# Altium schematic sheet 05-User-SMAs.SchDoc(05-User-SMAs)
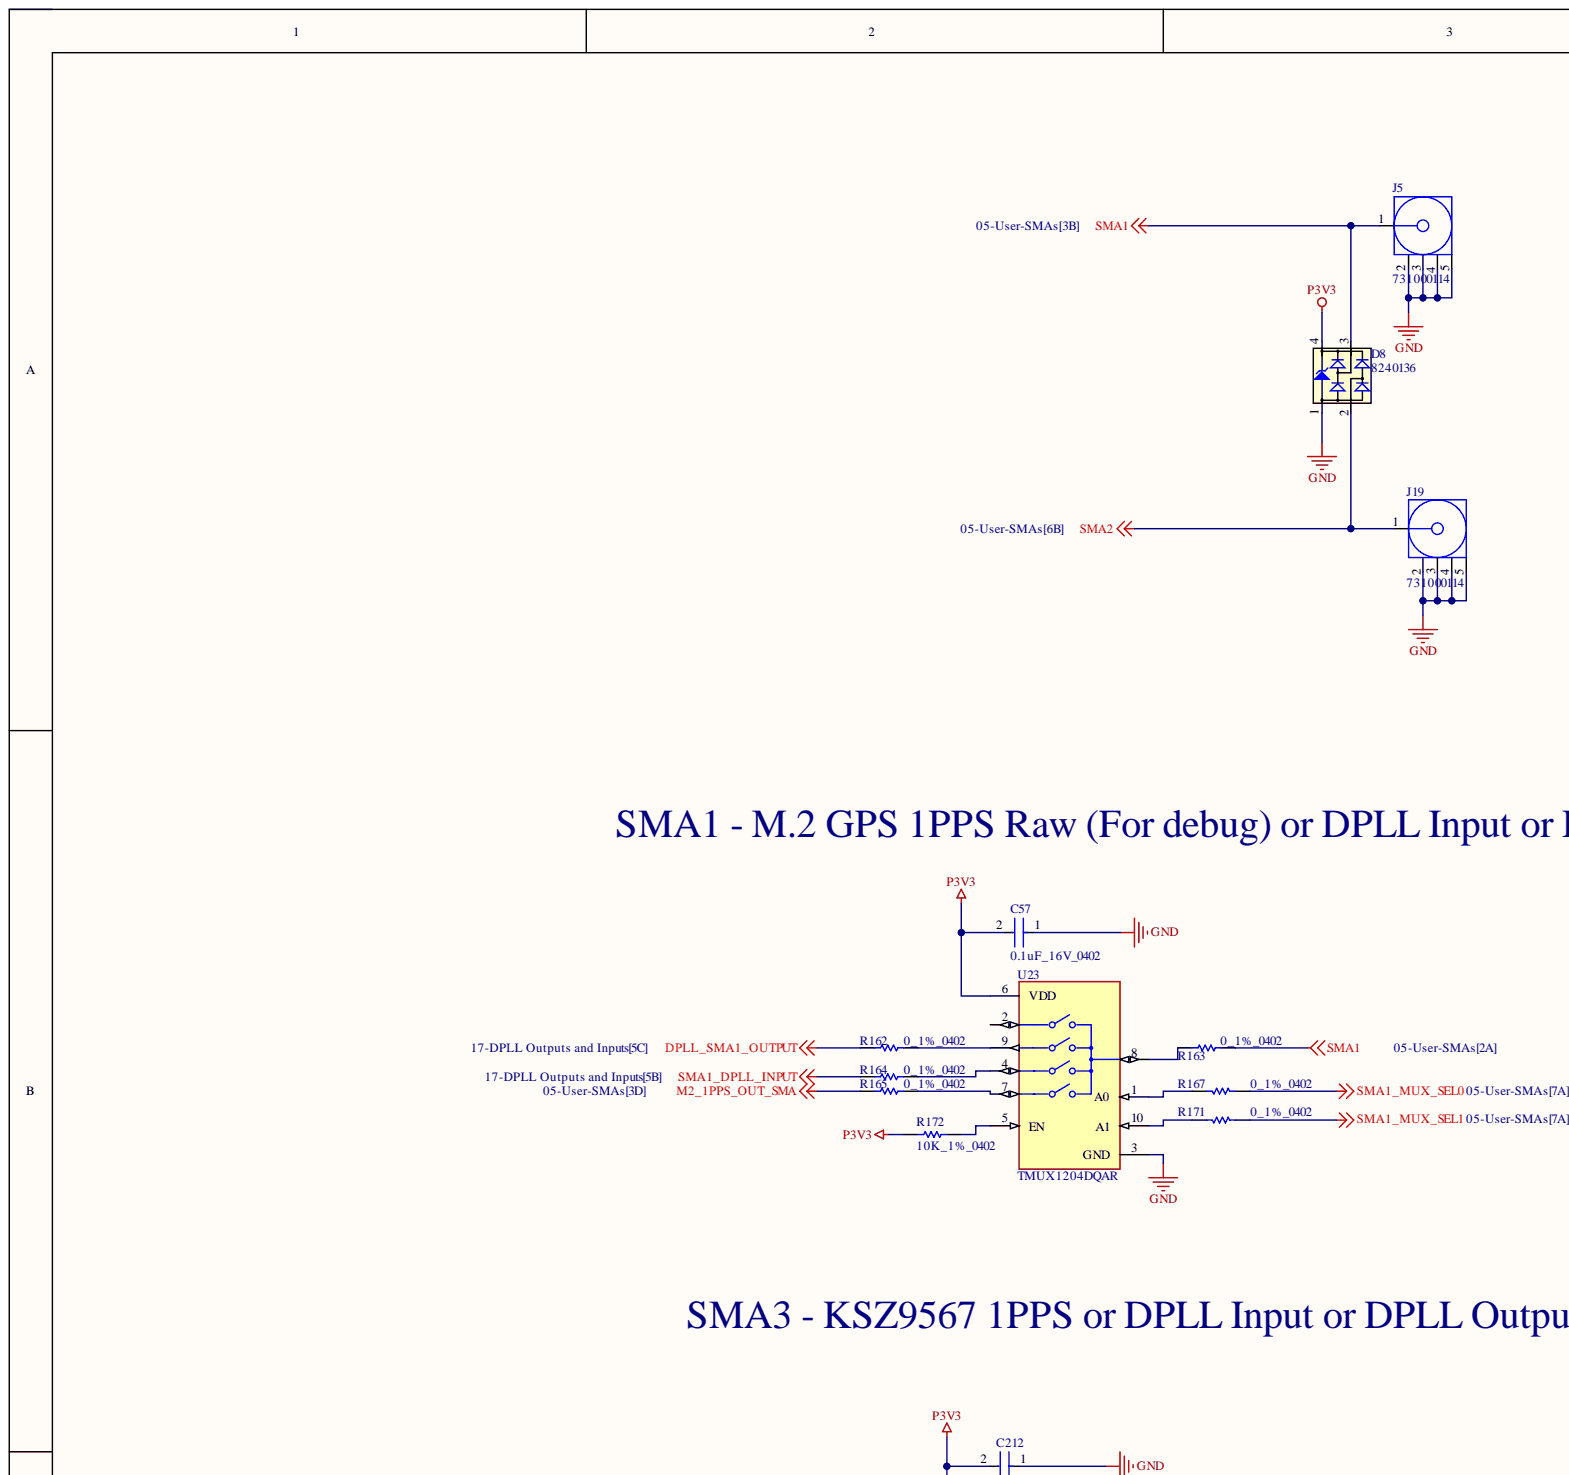
[diagram p0: 05-User-SMAs.SchDoc, top left]
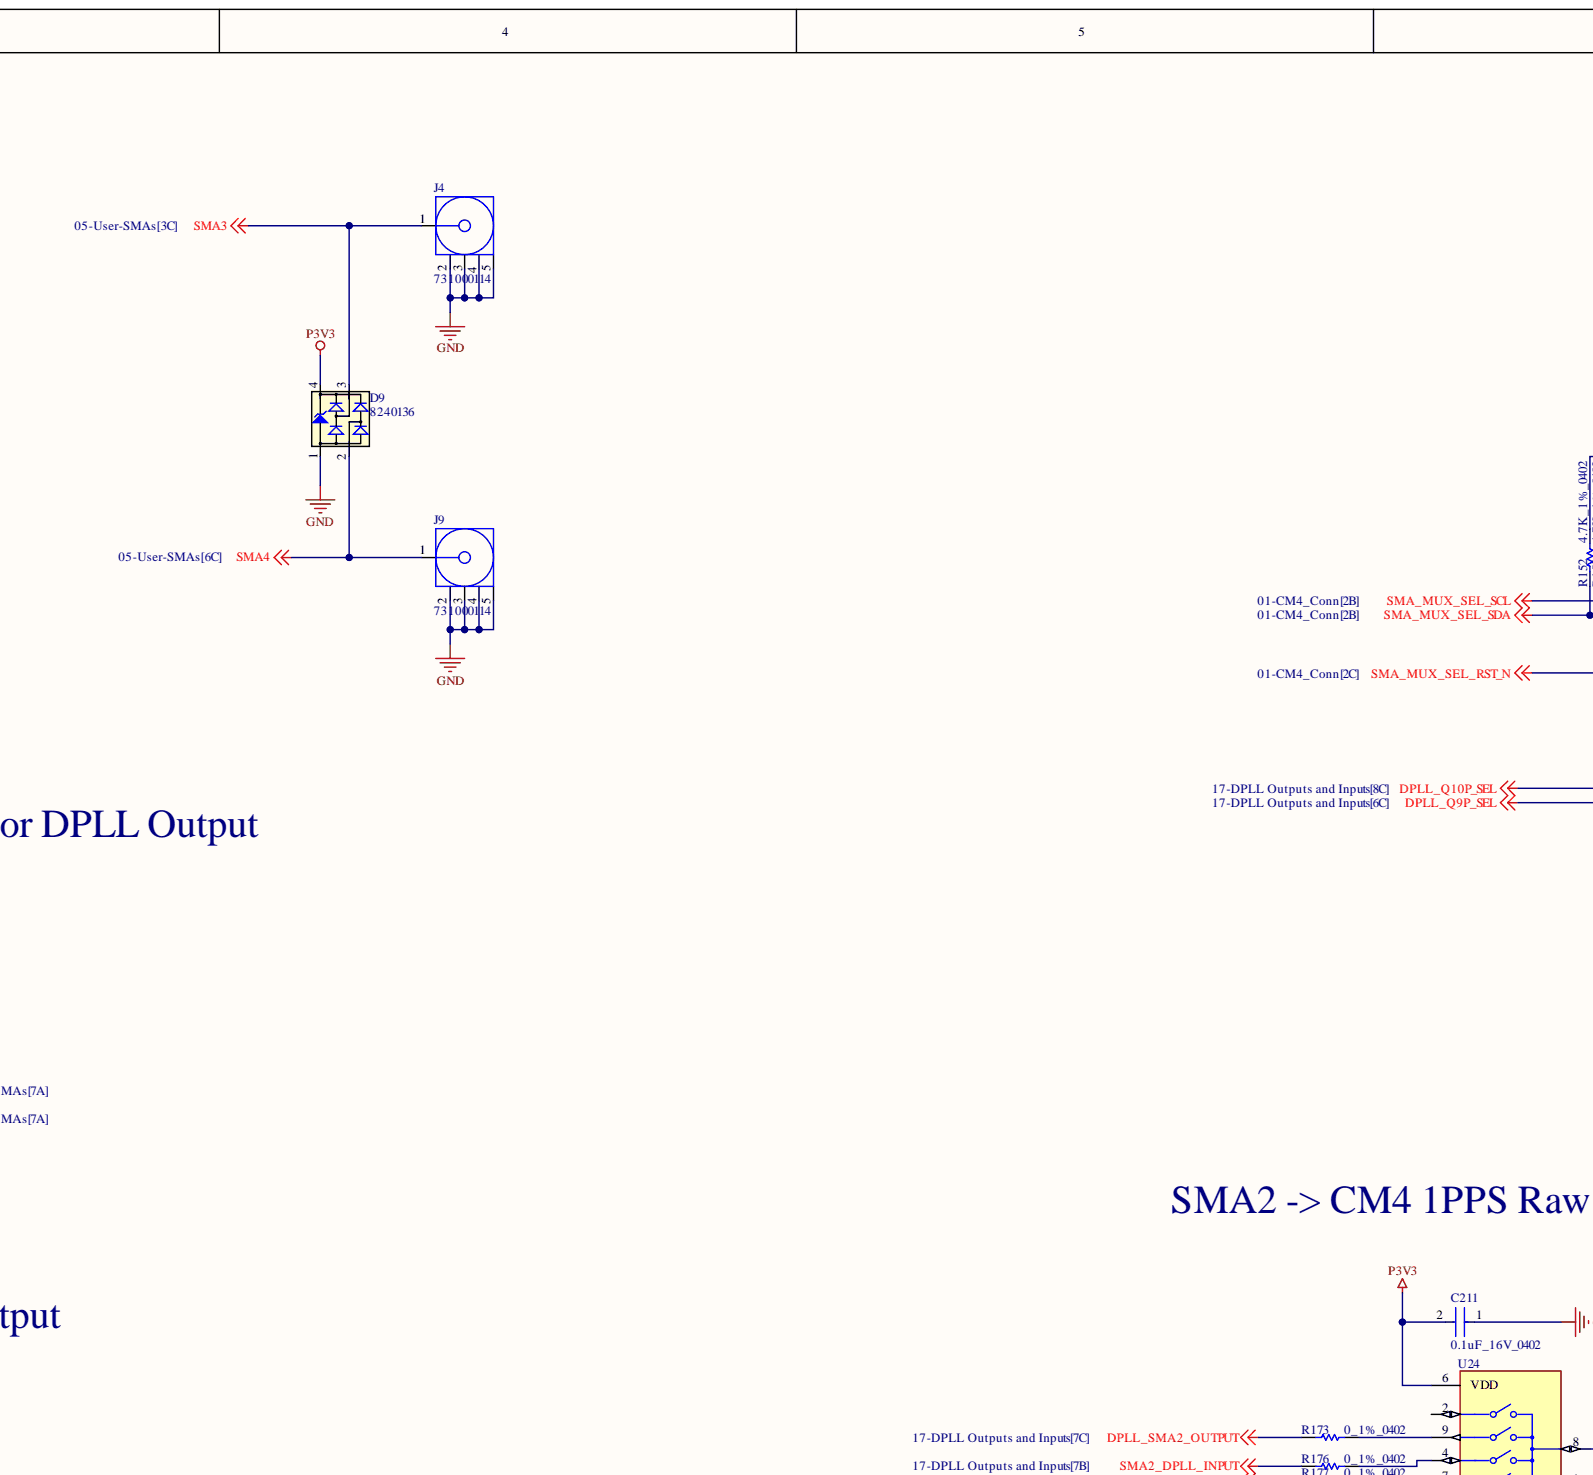
[diagram p1: 05-User-SMAs.SchDoc, top centre]
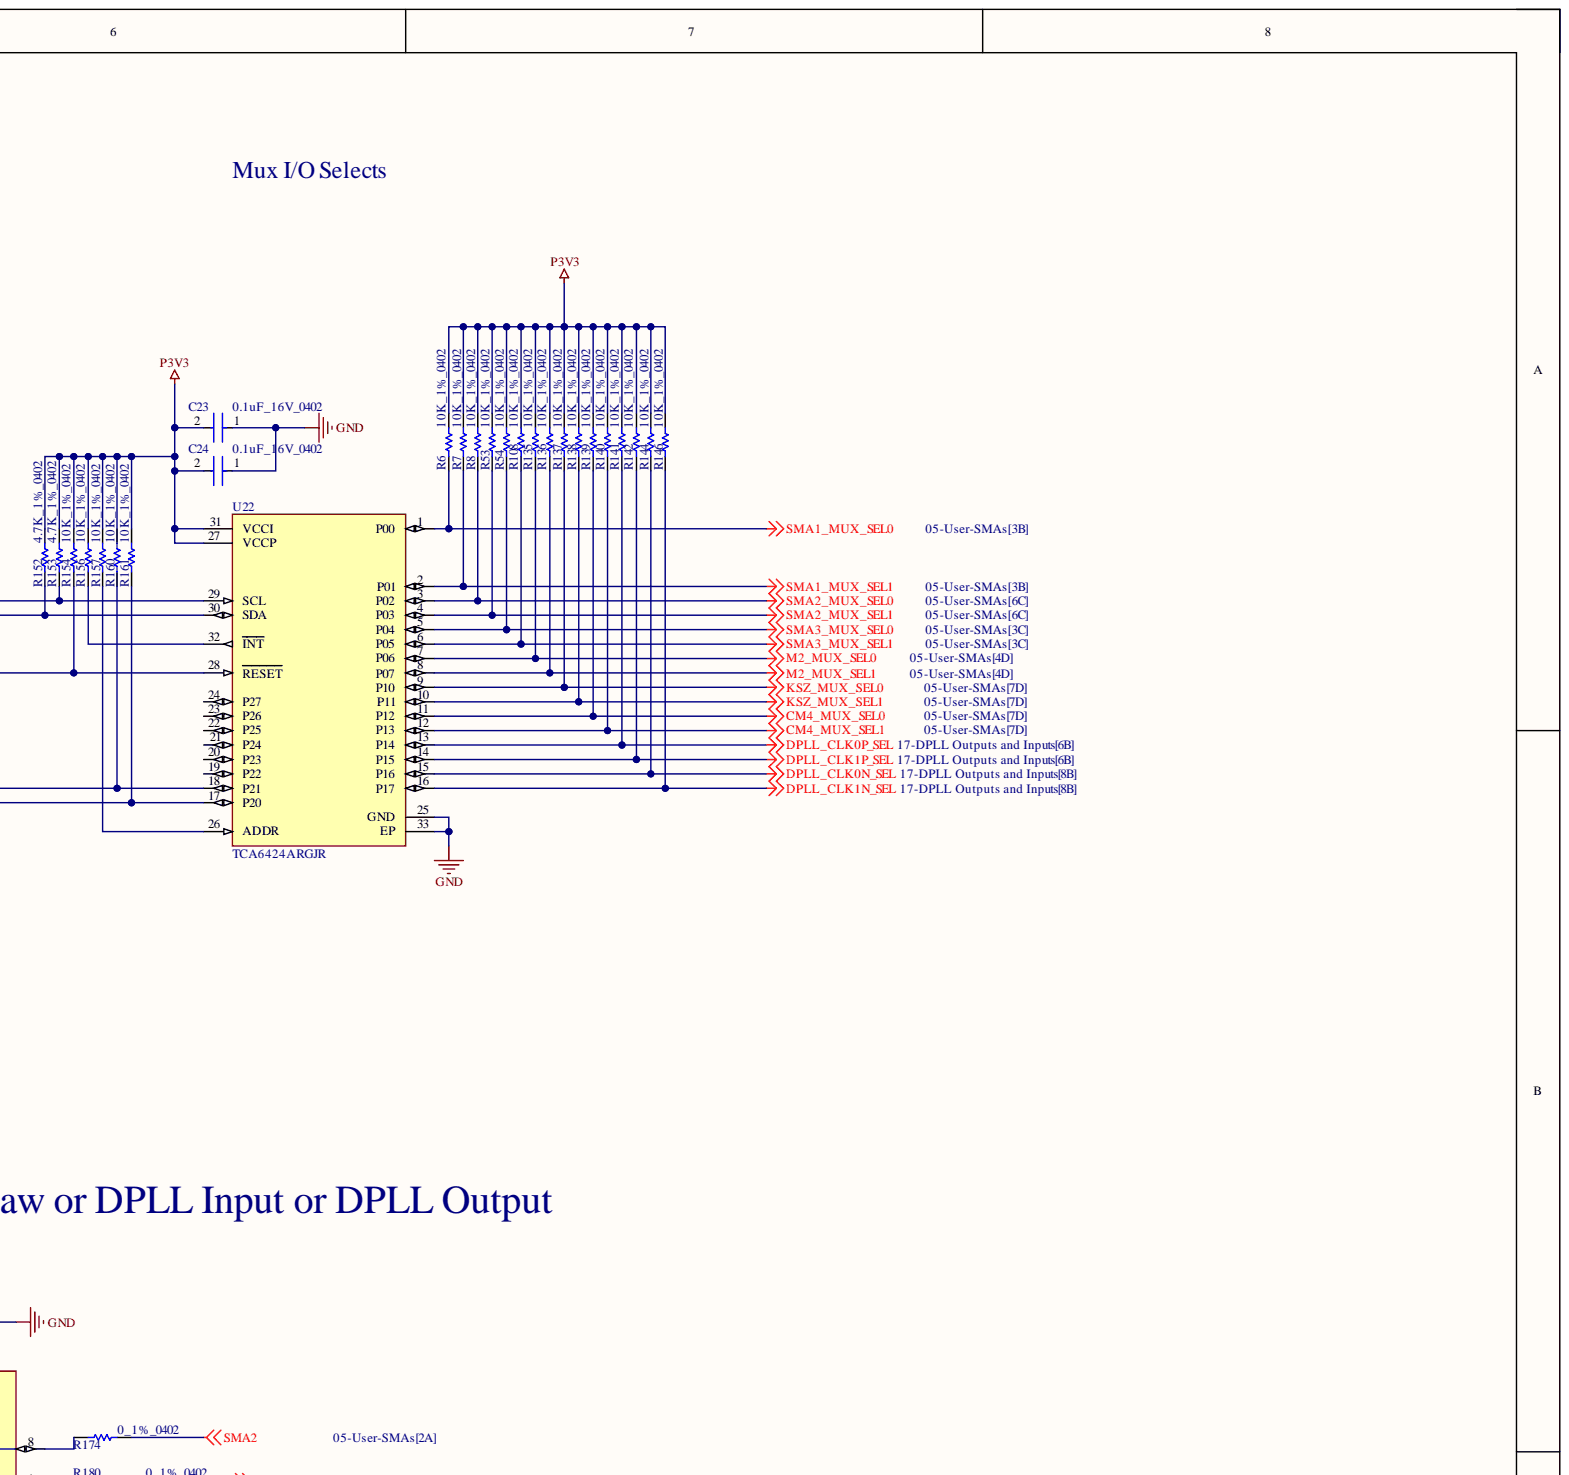
[diagram p2: 05-User-SMAs.SchDoc, top right]
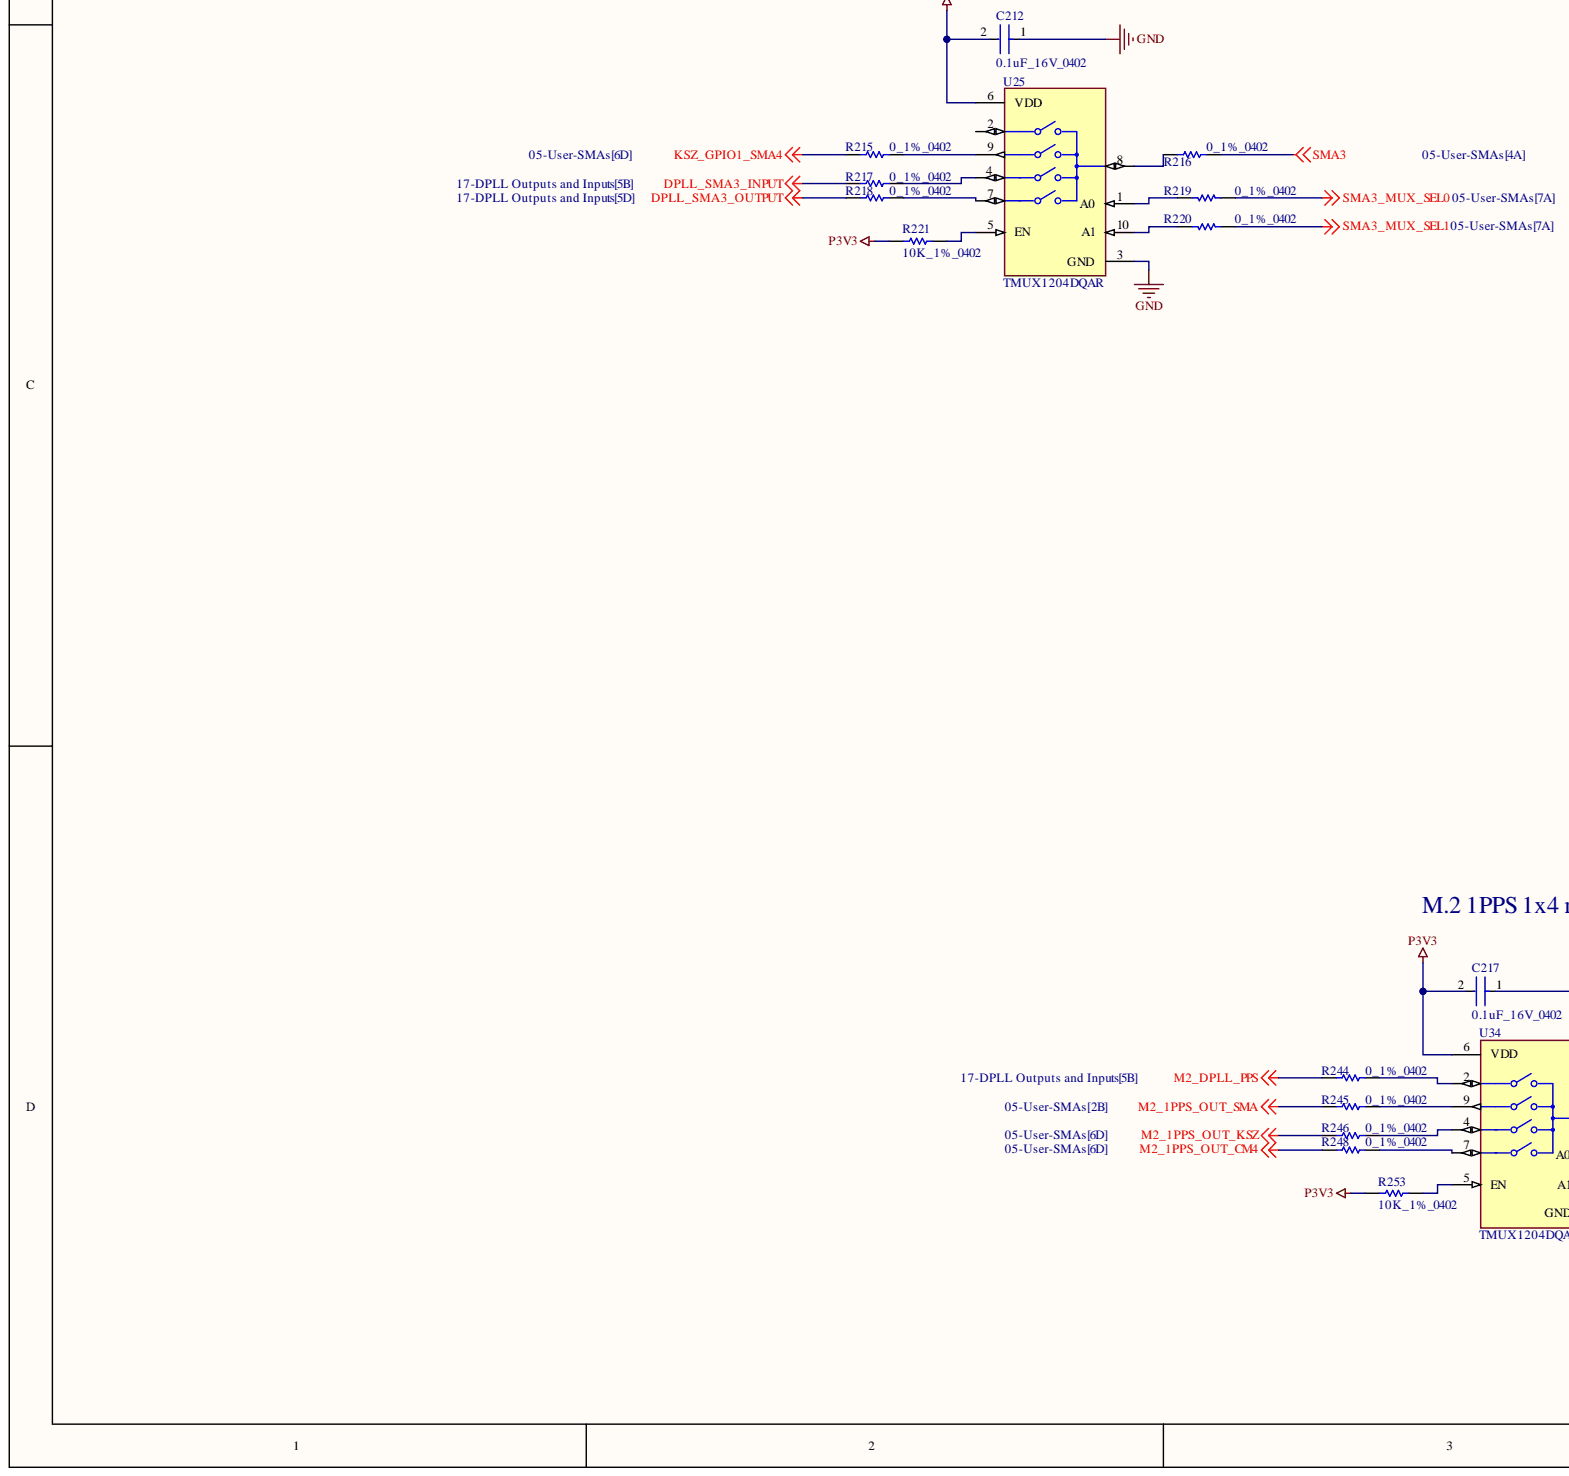
[diagram p3: 05-User-SMAs.SchDoc, bottom left]
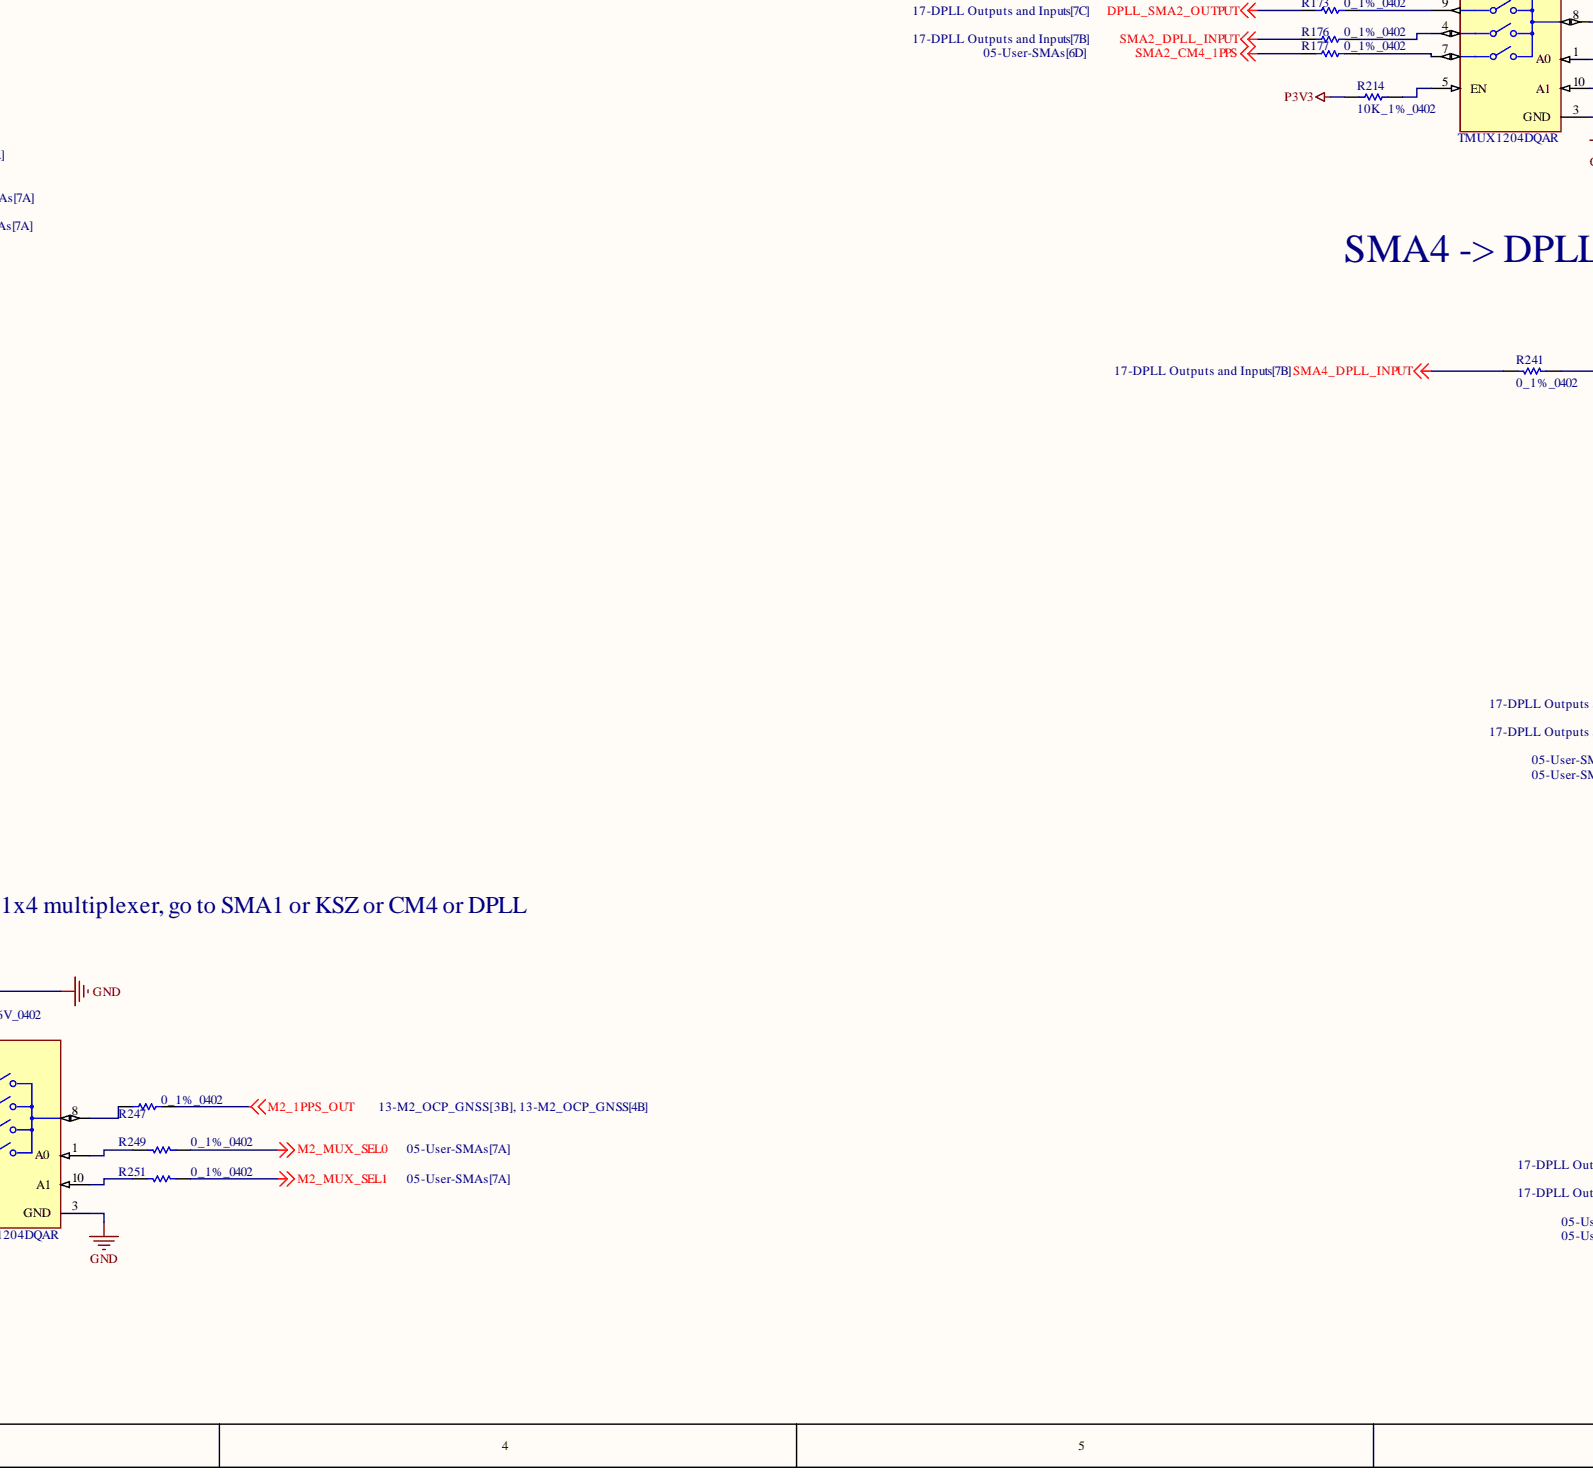
[diagram p4: 05-User-SMAs.SchDoc, bottom centre]
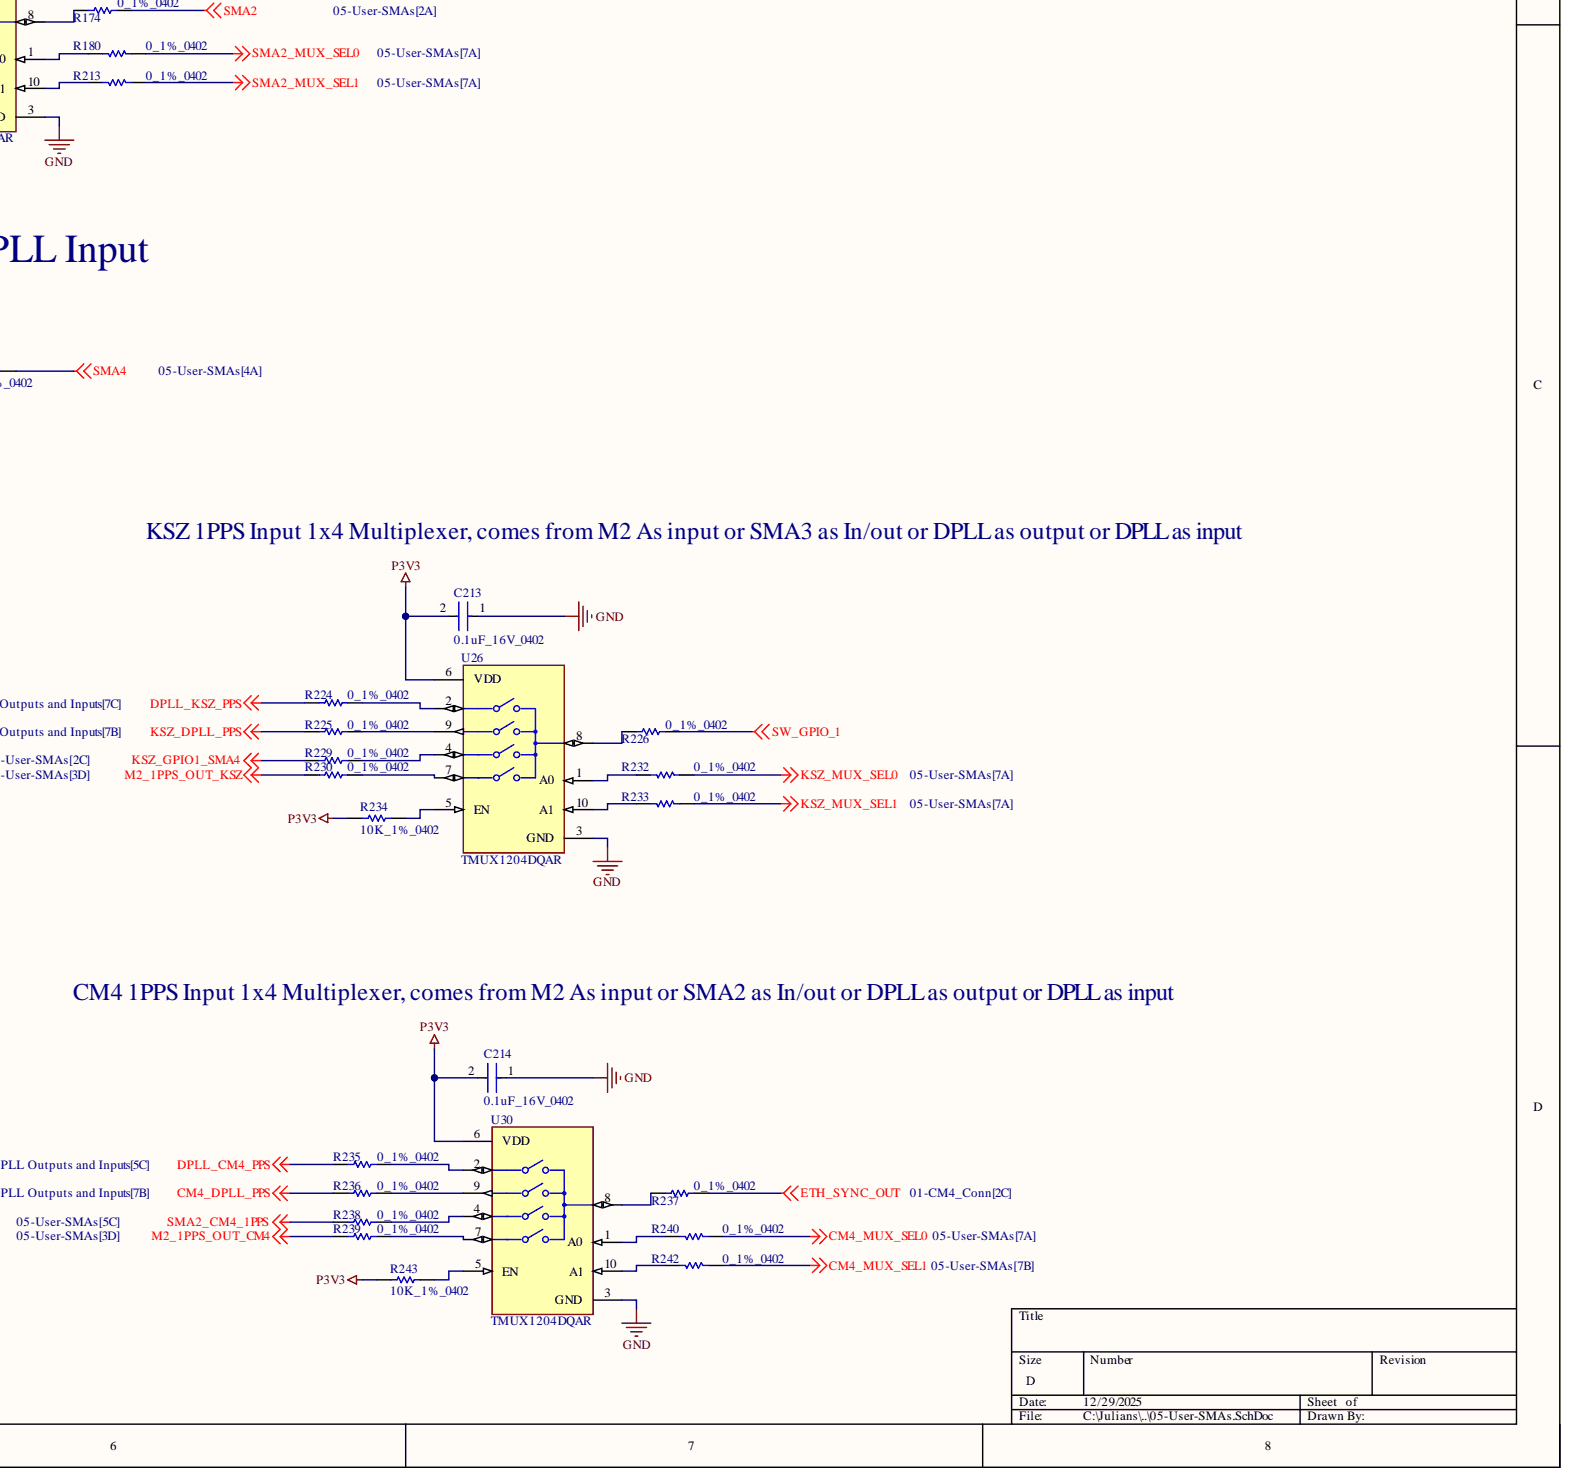
[diagram p5: 05-User-SMAs.SchDoc, bottom right]

## Parts
C23  0.1uF_16V_0402  [FP-C0402-BB-MFG]
C24  0.1uF_16V_0402  [FP-C0402-BB-MFG]
C57  0.1uF_16V_0402  [FP-C0402-BB-MFG]
C211  0.1uF_16V_0402  [FP-C0402-BB-MFG]
C212  0.1uF_16V_0402  [FP-C0402-BB-MFG]
C213  0.1uF_16V_0402  [FP-C0402-BB-MFG]
C214  0.1uF_16V_0402  [FP-C0402-BB-MFG]
C217  0.1uF_16V_0402  [FP-C0402-BB-MFG]
D8  8240136  [SOT143-4L]
D9  8240136  [SOT143-4L]
J4  731000114  [MOLX-731000114_V]
J5  731000114  [MOLX-731000114_V]
J9  731000114  [MOLX-731000114_V]
J19  731000114  [MOLX-731000114_V]
R6  10K_1%_0402  [RESC1005X40X25NL10T10]
R7  10K_1%_0402  [RESC1005X40X25NL10T10]
R8  10K_1%_0402  [RESC1005X40X25NL10T10]
R53  10K_1%_0402  [RESC1005X40X25NL10T10]
R54  10K_1%_0402  [RESC1005X40X25NL10T10]
R108  10K_1%_0402  [RESC1005X40X25NL10T10]
R135  10K_1%_0402  [RESC1005X40X25NL10T10]
R136  10K_1%_0402  [RESC1005X40X25NL10T10]
R137  10K_1%_0402  [RESC1005X40X25NL10T10]
R138  10K_1%_0402  [RESC1005X40X25NL10T10]
R139  10K_1%_0402  [RESC1005X40X25NL10T10]
R140  10K_1%_0402  [RESC1005X40X25NL10T10]
R141  10K_1%_0402  [RESC1005X40X25NL10T10]
R142  10K_1%_0402  [RESC1005X40X25NL10T10]
R144  10K_1%_0402  [RESC1005X40X25NL10T10]
R146  10K_1%_0402  [RESC1005X40X25NL10T10]
R152  4.7K_1%_0402  [RESC1005X40X25NL10T10]
R153  4.7K_1%_0402  [RESC1005X40X25NL10T10]
R154  10K_1%_0402  [RESC1005X40X25NL10T10]
R156  10K_1%_0402  [RESC1005X40X25NL10T10]
R157  10K_1%_0402  [RESC1005X40X25NL10T10]
R160  10K_1%_0402  [RESC1005X40X25NL10T10]
R161  10K_1%_0402  [RESC1005X40X25NL10T10]
R162  0_1%_0402  [RESC1005X40X25LL05T05]
R163  0_1%_0402  [RESC1005X40X25LL05T05]
R164  0_1%_0402  [RESC1005X40X25LL05T05]
R165  0_1%_0402  [RESC1005X40X25LL05T05]
R167  0_1%_0402  [RESC1005X40X25LL05T05]
R171  0_1%_0402  [RESC1005X40X25LL05T05]
R172  10K_1%_0402  [RESC1005X40X25NL10T10]
R173  0_1%_0402  [RESC1005X40X25LL05T05]
R174  0_1%_0402  [RESC1005X40X25LL05T05]
R176  0_1%_0402  [RESC1005X40X25LL05T05]
R177  0_1%_0402  [RESC1005X40X25LL05T05]
R180  0_1%_0402  [RESC1005X40X25LL05T05]
R213  0_1%_0402  [RESC1005X40X25LL05T05]
R214  10K_1%_0402  [RESC1005X40X25NL10T10]
R215  0_1%_0402  [RESC1005X40X25LL05T05]
R216  0_1%_0402  [RESC1005X40X25LL05T05]
R217  0_1%_0402  [RESC1005X40X25LL05T05]
R218  0_1%_0402  [RESC1005X40X25LL05T05]
R219  0_1%_0402  [RESC1005X40X25LL05T05]
R220  0_1%_0402  [RESC1005X40X25LL05T05]
R221  10K_1%_0402  [RESC1005X40X25NL10T10]
R224  0_1%_0402  [RESC1005X40X25LL05T05]
R225  0_1%_0402  [RESC1005X40X25LL05T05]
R226  0_1%_0402  [RESC1005X40X25LL05T05]
R229  0_1%_0402  [RESC1005X40X25LL05T05]
R230  0_1%_0402  [RESC1005X40X25LL05T05]
R232  0_1%_0402  [RESC1005X40X25LL05T05]
R233  0_1%_0402  [RESC1005X40X25LL05T05]
R234  10K_1%_0402  [RESC1005X40X25NL10T10]
R235  0_1%_0402  [RESC1005X40X25LL05T05]
R236  0_1%_0402  [RESC1005X40X25LL05T05]
R237  0_1%_0402  [RESC1005X40X25LL05T05]
R238  0_1%_0402  [RESC1005X40X25LL05T05]
R239  0_1%_0402  [RESC1005X40X25LL05T05]
R240  0_1%_0402  [RESC1005X40X25LL05T05]
R241  0_1%_0402  [RESC1005X40X25LL05T05]
R242  0_1%_0402  [RESC1005X40X25LL05T05]
R243  10K_1%_0402  [RESC1005X40X25NL10T10]
R244  0_1%_0402  [RESC1005X40X25LL05T05]
R245  0_1%_0402  [RESC1005X40X25LL05T05]
R246  0_1%_0402  [RESC1005X40X25LL05T05]
R247  0_1%_0402  [RESC1005X40X25LL05T05]
R248  0_1%_0402  [RESC1005X40X25LL05T05]
R249  0_1%_0402  [RESC1005X40X25LL05T05]
R251  0_1%_0402  [RESC1005X40X25LL05T05]
R253  10K_1%_0402  [RESC1005X40X25NL10T10]
U22  TCA6424ARGJR  [FP-RGJ0032A-MFG]
U23  TMUX1204DQAR  [FP-DQA0010A-MFG]
U24  TMUX1204DQAR  [FP-DQA0010A-MFG]
U25  TMUX1204DQAR  [FP-DQA0010A-MFG]
U26  TMUX1204DQAR  [FP-DQA0010A-MFG]
U30  TMUX1204DQAR  [FP-DQA0010A-MFG]
U34  TMUX1204DQAR  [FP-DQA0010A-MFG]

## Nets
CM4_DPLL_PPS: R236.1
CM4_MUX_SEL0: R139.1 R240.1 U22.11(P12)
CM4_MUX_SEL1: R140.1 R242.1 U22.12(P13)
DPLL_CLK0N_SEL: R144.1 U22.15(P16)
DPLL_CLK0P_SEL: R141.1 U22.13(P14)
DPLL_CLK1N_SEL: R146.1 U22.16(P17)
DPLL_CLK1P_SEL: R142.1 U22.14(P15)
DPLL_CM4_PPS: R235.1
DPLL_KSZ_PPS: R224.1
DPLL_Q9P_SEL: R161.1 U22.17(P20)
DPLL_Q10P_SEL: R160.1 U22.18(P21)
DPLL_SMA1_OUTPUT: R162.1
DPLL_SMA2_OUTPUT: R173.1
DPLL_SMA3_INPUT: R217.1
DPLL_SMA3_OUTPUT: R218.1
ETH_SYNC_OUT: R237.2
GND: C23.1 C24.1 C57.1 C211.1 C212.1 C213.1 C214.1 C217.1 D8.1 D9.1 J4.2 J4.3 J4.4 J4.5 J5.2 J5.3 J5.4 J5.5 J9.2 J9.3 J9.4 J9.5 J19.2 J19.3 J19.4 J19.5 U22.25(GND) U22.33(EP) U23.3(GND) U24.3(GND) U25.3(GND) U26.3(GND) U30.3(GND) U34.3(GND)
KSZ_DPLL_PPS: R225.1
KSZ_GPIO1_SMA4: R215.1 R229.1
KSZ_MUX_SEL0: R137.1 R232.1 U22.9(P10)
KSZ_MUX_SEL1: R138.1 R233.1 U22.10(P11)
M2_1PPS_OUT: R247.2
M2_1PPS_OUT_CM4: R239.1 R248.1
M2_1PPS_OUT_KSZ: R230.1 R246.1
M2_1PPS_OUT_SMA: R165.1 R245.1
M2_DPLL_PPS: R244.1
M2_MUX_SEL0: R135.1 R249.1 U22.7(P06)
M2_MUX_SEL1: R136.1 R251.1 U22.8(P07)
P3V3: C23.2 C24.2 C57.2 C211.2 C212.2 C213.2 C214.2 C217.2 D8.4 D9.4 R6.2 R7.2 R8.2 R53.2 R54.2 R108.2 R135.2 R136.2 R137.2 R138.2 R139.2 R140.2 R141.2 R142.2 R144.2 R146.2 R152.1 R153.1 R154.2 R156.2 R157.2 R160.2 R161.2 R172.2 R214.2 R221.2 R234.2 R243.2 R253.2 U22.27(VCCP) U22.31(VCCI) U23.6(VDD) U24.6(VDD) U25.6(VDD) U26.6(VDD) U30.6(VDD) U34.6(VDD)
SMA1: D8.3 J5.1 R163.2
SMA1_DPLL_INPUT: R164.1
SMA1_MUX_SEL0: R6.1 R167.1 U22.1(P00)
SMA1_MUX_SEL1: R7.1 R171.1 U22.2(P01)
SMA2: D8.2 J19.1 R174.2
SMA2_CM4_1PPS: R177.1 R238.1
SMA2_DPLL_INPUT: R176.1
SMA2_MUX_SEL0: R8.1 R180.1 U22.3(P02)
SMA2_MUX_SEL1: R53.1 R213.1 U22.4(P03)
SMA3: D9.3 J4.1 R216.2
SMA3_MUX_SEL0: R54.1 R219.1 U22.5(P04)
SMA3_MUX_SEL1: R108.1 R220.1 U22.6(P05)
SMA4: D9.2 J9.1 R241.1
SMA4_DPLL_INPUT: R241.2
SMA_MUX_SEL_RST_N: R154.1 U22.28(RESET)
SMA_MUX_SEL_SCL: R153.2 U22.29(SCL)
SMA_MUX_SEL_SDA: R152.2 U22.30(SDA)
SW_GPIO_1: R226.2
NetR156_1: R156.1 U22.32(INT)
NetR157_1: R157.1 U22.26(ADDR)
NetR162_2: R162.2 U23.9
NetR163_1: R163.1 U23.8
NetR164_2: R164.2 U23.4
NetR165_2: R165.2 U23.7
NetR167_2: R167.2 U23.1(A0)
NetR171_2: R171.2 U23.10(A1)
NetR172_1: R172.1 U23.5(EN)
NetR173_2: R173.2 U24.9
NetR174_1: R174.1 U24.8
NetR176_2: R176.2 U24.4
NetR177_2: R177.2 U24.7
NetR180_2: R180.2 U24.1(A0)
NetR213_2: R213.2 U24.10(A1)
NetR214_1: R214.1 U24.5(EN)
NetR215_2: R215.2 U25.9
NetR216_1: R216.1 U25.8
NetR217_2: R217.2 U25.4
NetR218_2: R218.2 U25.7
NetR219_2: R219.2 U25.1(A0)
NetR220_2: R220.2 U25.10(A1)
NetR221_1: R221.1 U25.5(EN)
NetR224_2: R224.2 U26.2
NetR225_2: R225.2 U26.9
NetR226_1: R226.1 U26.8
NetR229_2: R229.2 U26.4
NetR230_2: R230.2 U26.7
NetR232_2: R232.2 U26.1(A0)
NetR233_2: R233.2 U26.10(A1)
NetR234_1: R234.1 U26.5(EN)
NetR235_2: R235.2 U30.2
NetR236_2: R236.2 U30.9
NetR237_1: R237.1 U30.8
NetR238_2: R238.2 U30.4
NetR239_2: R239.2 U30.7
NetR240_2: R240.2 U30.1(A0)
NetR242_2: R242.2 U30.10(A1)
NetR243_1: R243.1 U30.5(EN)
NetR244_2: R244.2 U34.2
NetR245_2: R245.2 U34.9
NetR246_2: R246.2 U34.4
NetR247_1: R247.1 U34.8
NetR248_2: R248.2 U34.7
NetR249_2: R249.2 U34.1(A0)
NetR251_2: R251.2 U34.10(A1)
NetR253_1: R253.1 U34.5(EN)

Not connected: U22 19(P22) 20(P23) 21(P24) 22(P25) 23(P26) 24(P27); U23 2; U24 2; U25 2

## Library data of the parts
C23 C24 C57 C211-C214 C217 = CMP-1034-02068-3: CAP CER 0.1UF 16V 5% X7R 0402; Manufacturer: Kemet; Manufacturer Part Number: C0402C104J4RACTU; Capacitance: 0.10 μF; Material: Ceramic; Temperature Coefficient: 15%; Tolerance: 0.05; Value: 0.10 μF; Automotive: No; Category: Cap; Component Kind: Standard; ComponentLink2Description: Datasheet; ComponentLink2URL: https://content.kemet.com/datasheets/KEM_C1002_X7R_SMD.pdf; Device Class L1: Passive Components; Device Class L2: Capacitors; Device Class L3: Ceramic Capacitors; Digikey Description: CAP CER 0.1UF 16V 5% X7R 0402; Height: 0.55mm; Ipc Land Pattern Name: CAPC10050X50; Lead Free: Yes; Library Name: Altium Content Vault; Mouser Description: CAP CER 0.1UF 16V X7R 0402; Octopart: https://octopart.com/c0402c104j4ractu-kemet-12573893; Package: C0402-BB; RoHS: TRUE; Temperature: -55°C to +125°C; Temperature Characteristic: X7R; Temperature Range High: +125°C; Temperature Range Low: -55°C; Voltage Rating: 16 V
D8 D9 = CMP-1486-00002-1: TVS Diode WE-TVS-HS, VRWM=3.3V; Manufacturer: Wuerth Elektronik; Reverse Stand Off Voltage: 3.3V; Component Kind: Standard; ComponentLink1Description: Manufacturer URL; ComponentLink1URL: http://www.we-online.com; ComponentLink2Description: Datasheet; ComponentLink2URL: http://katalog.we-online.de/kataloge/eisos/media/pdf/8240136.pdf; Library Name: Altium Content Vault; PackageReference: SOT143-4L; PartNumber: 8240136
J4 J5 J9 J19 = CMP-2000-05487-1: SMA Right-Angle PCB Jack Receptacle, Brass Body, Gold Plated, 50 Ohm, 5-Pin THD, RoHS, Tray; Manufacturer: Molex; Component Kind: Standard; Datasheet URL: http://www.molex.com/webdocs/datasheets/pdf/en-us/0731000114_RF_COAX_CONNECTORS.pdf; Datasheet Version: 09/2016; Library Name: Altium Content Vault; Manufacturer URL: http://www.molex.com/; Mounting Technology: ThroughHole; Package Description: 5-Pin Through Hole Device, Body 14.8 x 6.35 mm, Pitch 2.54 mm; Package Reference: 731000114; Package URL: http://www.molex.com/pdm_docs/sd/731000114_sd.pdf
R6-R8 R53 R54 R108 R135-R142 R144 R146 R154 R156 R157 R160 R161 R172 R214 R221 R234 R243 R253 = CMP-2002-04694-1: Manufacturer: Bourns; Part Number: CR0402-FX-1002GLF; Component Kind: Standard; Library Name: Altium Content Vault
R152 R153 = CMP-2002-05217-1: Manufacturer: Bourns; Part Number: CR0402-FX-4701GLF; Component Kind: Standard; Library Name: Altium Content Vault
R162-R165 R167 R171 R173 R174 R176 R177 R180 R213 R215-R220 R224-R226 R229 R230 R232 R233 R235-R242 R244-R249 R251 = CMP-2000-07451-1: Chip Resistor, 0 Ohm, 0.1 W, -55 to 155 degC, 0402 (1005 Metric), RoHS, Tape and Reel; Manufacturer: Panasonic; Max Power Dissipation: 100mW; Resistance: 0mOhm; Temperature Coefficient: 600ppm/��C; Tolerance: 5%; Voltage Rating: 50V; Case Code (Imperial): 0402; Case Code (Metric): 1005; Case/Package: 0402; Component Kind: Standard; Composition: ThickFilm; Contact Plating: Tin; Datasheet URL: https://industrial.panasonic.com/cdbs/www-data/pdf/RDA0000/AOA0000C301.pdf; Datasheet Version: 03/2015; Depth: 0.5mm; Height: 0.35mm; Lead Free: LeadFree; Length: 1mm; Library Name: Altium Content Vault; Manufacturer URL: http://www.panasonic.com/; Max Operating Temperature: 155degC; Military Standard: Not; Min Operating Temperature: -55degC; Mount: SurfaceMount; Mounting Technology: SurfaceMount; Number of Terminations: 2; Package Description: 2-Pin Surface Mount Device, Body 1 x 0.5 mm; Package Quantity: 1; Package Reference: 402; Packaging: TapeandReel; Power Rating: 100mW; Radiation Hardening: No; REACH SVHC: NoSVHC; RoHSCompliant: True; Termination: SMD/SMT; Voltage Rating (DC): 50V; Width: 0.02inch
U22 = CMP-0314-00048-3: IC I/O EXPANDER I2C 24B 32UQFN; Frequency: 400kHz; Max Supply Voltage: 5.5V; Min Supply Voltage: 1.65V; Number of I/Os: 24; Output Current: 25mA; Case/Package: QFN; Component Kind: Standard; Contact Plating: Gold; Height: 0.6mm; Lead Free: Lead Free; Length: 5.15mm; Library Name: Altium Content Vault; Max Frequency: 400kHz; Max Operating Temperature: 85°C; Min Operating Temperature: -40°C; Number of Outputs: 24; Number of Pins: 32; Package Quantity: 1; Packaging: Tape and Reel; Radiation Hardening: No; REACH SVHC: No SVHC; RoHS Compliant: Yes; Series: -; Weight: 0.002116oz; Width: 5.15mm
U23-U26 U30 U34 = CMP-04892-000027-1: IC SWITCH; Component Kind: Standard; Library Name: Altium Content Vault
